# Altium schematic sheet PowerMonitor.SchDoc
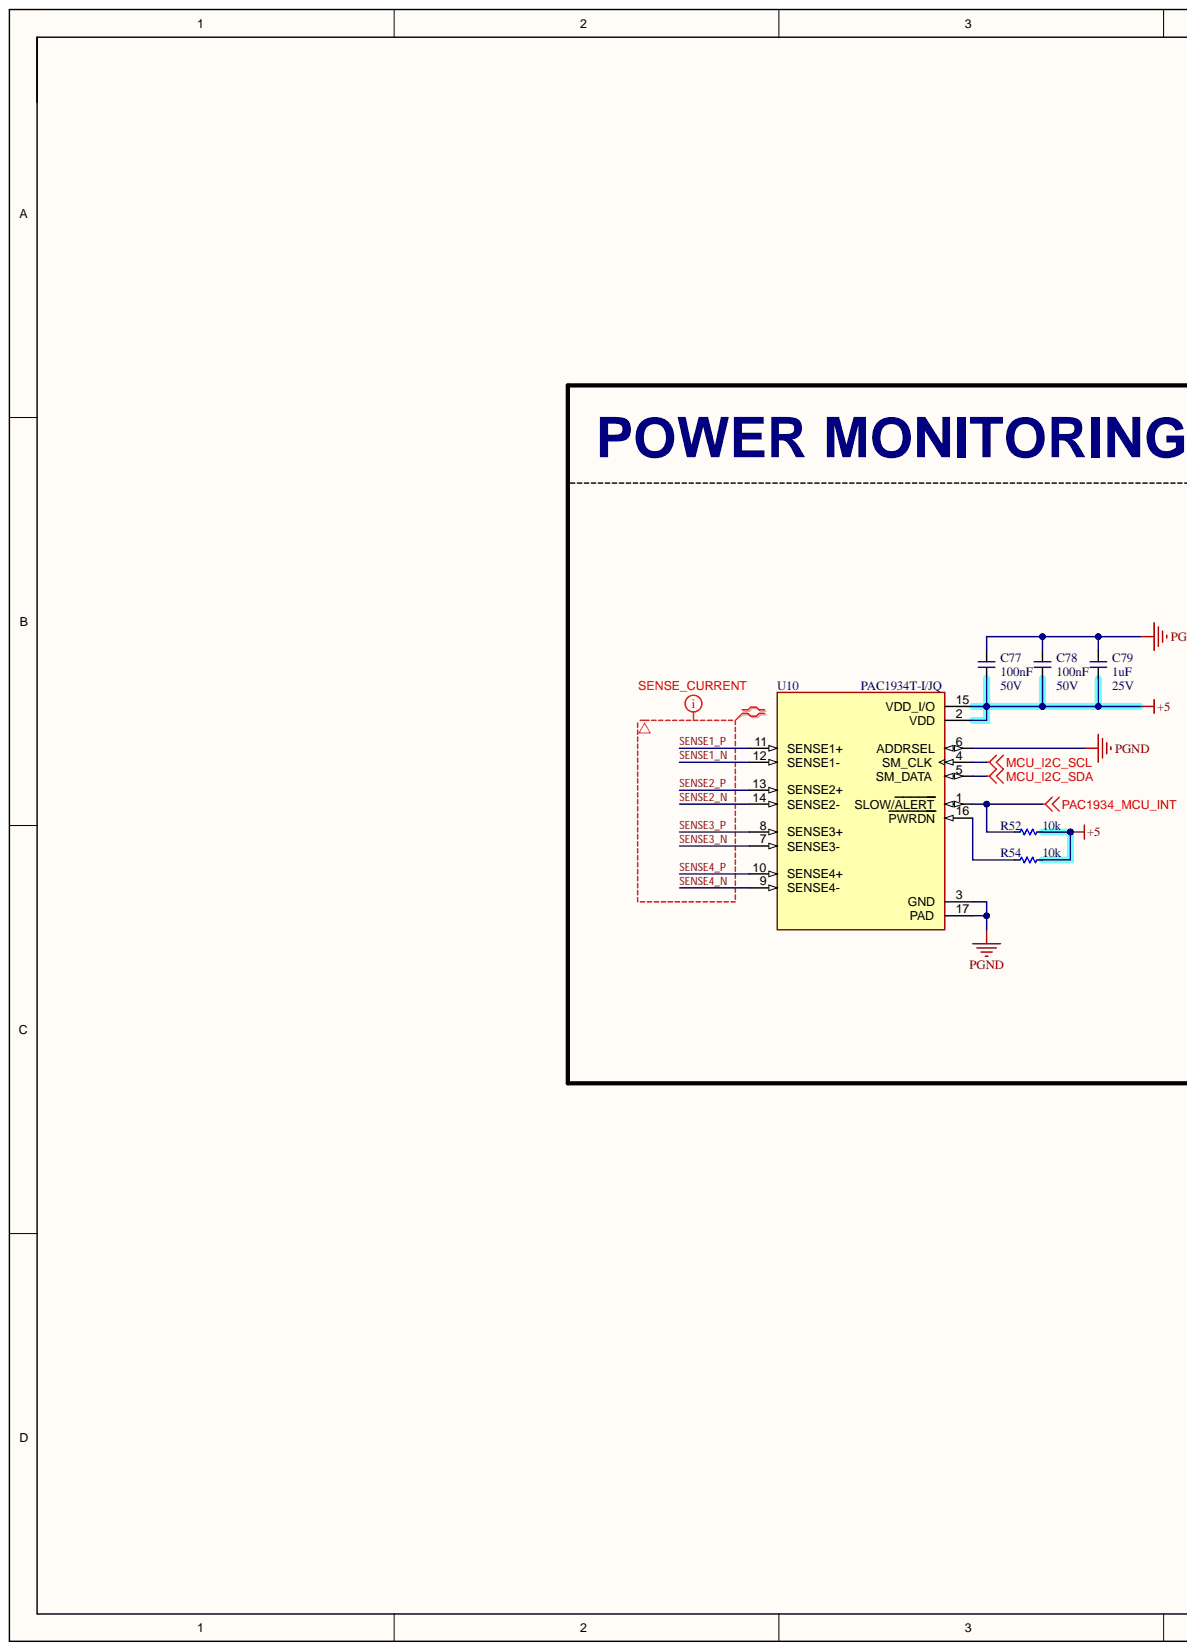
[diagram p0: PowerMonitor.SchDoc, left]
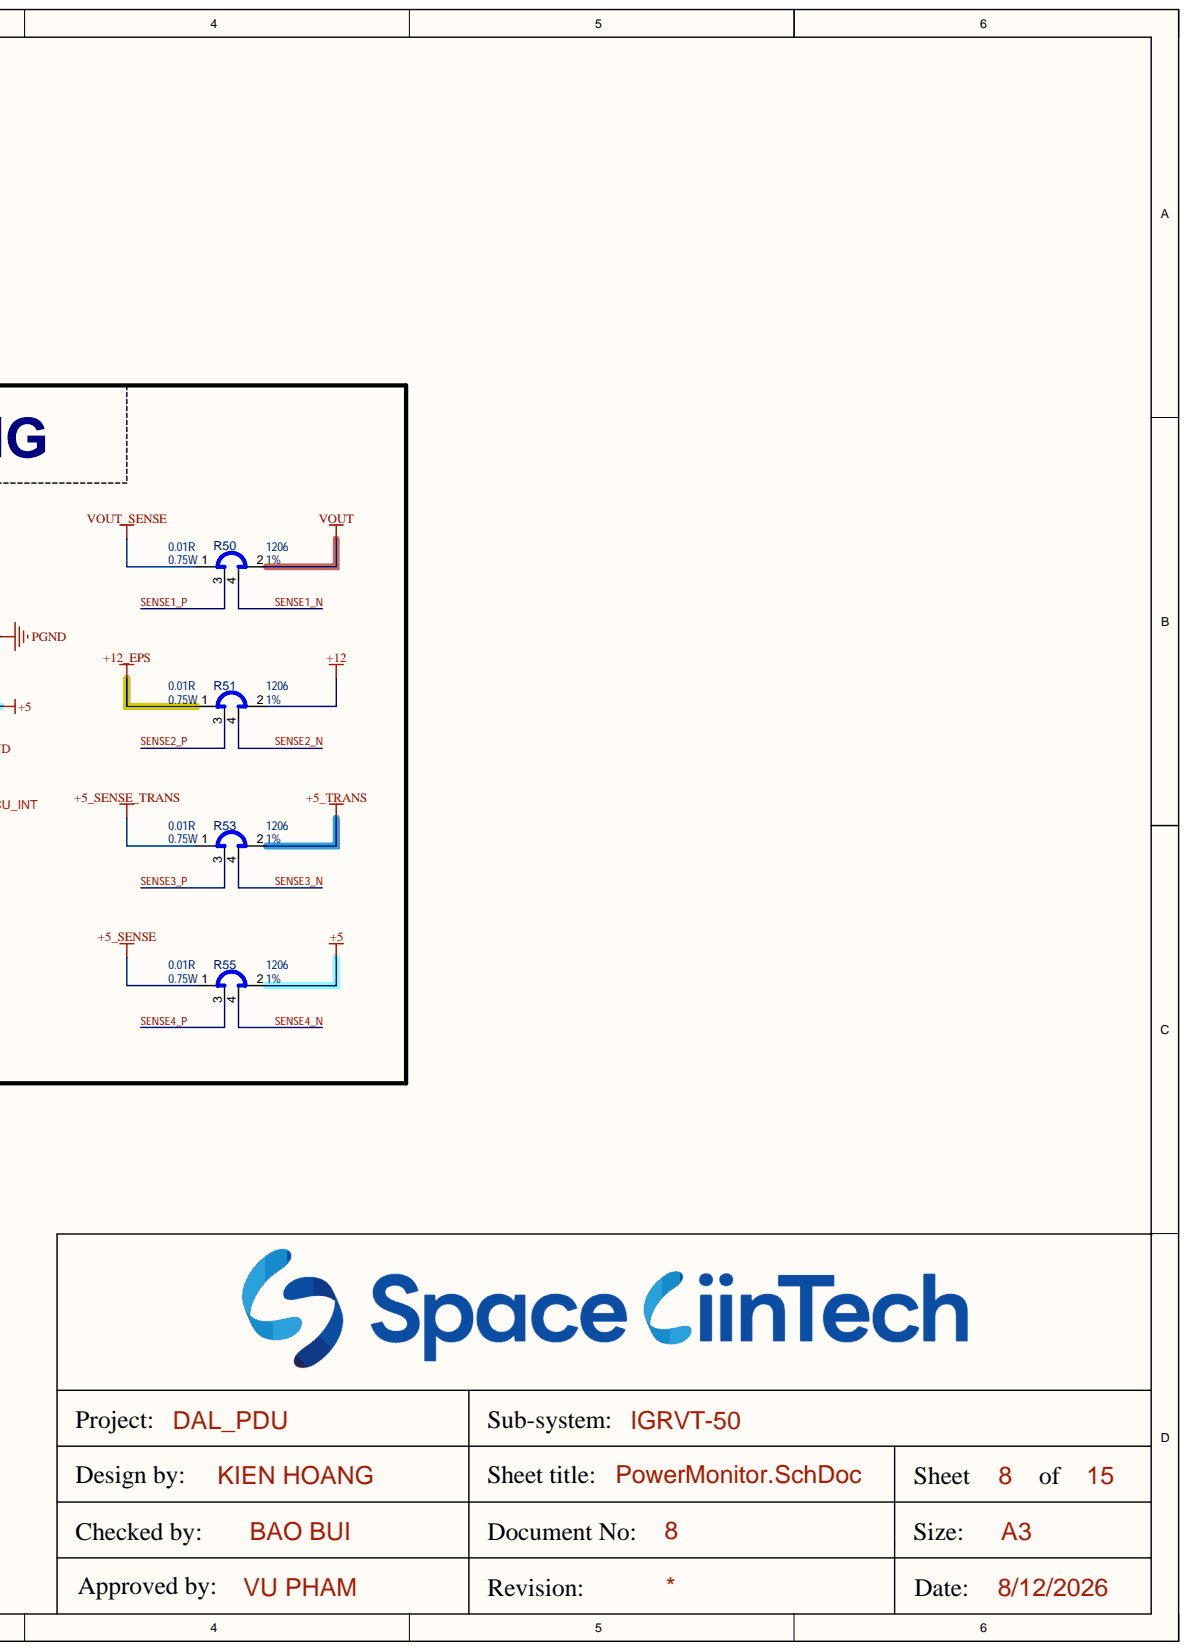
[diagram p1: PowerMonitor.SchDoc, right]

## Parts
C77  100nF  Voltage: 50V  [CAP_C_1608X80]
C78  100nF  Voltage: 50V  [CAP_C_1608X80]
C79  1uF  Voltage: 25V  [CAP_C_1608X80]
R50  value 0.01R  Package: 1206  Power: 0.75W  Tolerance: 1%  [RES_C_1206_SHUNT]  (hidden comment: Shunt 4 Pin)
R51  value 0.01R  Package: 1206  Power: 0.75W  Tolerance: 1%  [RES_C_1206_SHUNT]  (hidden comment: Shunt 4 Pin)
R52  10k  [RES_C_1608X60]
R53  value 0.01R  Package: 1206  Power: 0.75W  Tolerance: 1%  [RES_C_1206_SHUNT]  (hidden comment: Shunt 4 Pin)
R54  10k  [RES_C_1608X60]
R55  value 0.01R  Package: 1206  Power: 0.75W  Tolerance: 1%  [RES_C_1206_SHUNT]  (hidden comment: Shunt 4 Pin)
U10  PAC1934T-I/JQ  [QFN65]

## Nets
+5: C77.2 C78.2 C79.2 R52.1 R54.2 R55.2 U10.2(VDD) U10.15(VDD_I/O)
+5_SENSE: R55.1
+5_SENSE_TRANS: R53.1
+5_TRANS: R53.2
+12: R51.2
+12_EPS: R51.1
MCU_I2C_SCL: U10.4(SM_CLK)
MCU_I2C_SDA: U10.5(SM_DATA)
PAC1934_MCU_INT: R52.2 U10.1(SLOW/ALERT)
PGND: C77.1 C78.1 C79.1 U10.3(GND) U10.6(ADDRSEL) U10.17(PAD)
VOUT: R50.2
VOUT_SENSE: R50.1
SENSE1_N: R50.4 U10.12(SENSE1-)
SENSE1_P: R50.3 U10.11(SENSE1+)
SENSE2_N: R51.4 U10.14(SENSE2-)
SENSE2_P: R51.3 U10.13(SENSE2+)
SENSE3_N: R53.4 U10.7(SENSE3-)
SENSE3_P: R53.3 U10.8(SENSE3+)
SENSE4_N: R55.4 U10.9(SENSE4-)
SENSE4_P: R55.3 U10.10(SENSE4+)
NetR54_1: R54.1 U10.16(PWRDN)

## Library data of the parts
C77-C79 = _CAP_C_2: CAP; Component Kind: Standard; Library Name: LABLIB.SchLib
R50 R51 R53 R55 = RSMT1316: Shunt; Manufacturer 1: SUSUMU; Manufacturer Part Number 1: KRL1632E-M-R010-F-T5; Current: 8A; Description_: RES SHUNT MF 0.01R 1% 0.75W SMD 1206; Dev Tools Status: Design; Owner: Ciprian Abramov; Populated: YES; Temperature Coeffcient: 50ppm/C; Category: Resistor; Component Kind: Standard; ComponentLink1Description: SVN Datasheet; ComponentLink1URL: https://chn-vm-apps.microchip.com/mchprepo/parts/Datasheets/n_catalog_partition09_en.pdf; ComponentLink2Description: Local Datasheet; ComponentLink3Description: Open Symbol; ComponentLink4Description: Open Footprint; ComponentLink5Description: Open Footprint 2; ComponentLink6Description: Open Footprint 3; ComponentLink7Description: Open Footprint 4; Composition: MF; MCHP-ID: RSMT1316; Operating Temperature: -55C to +155C; Quantity Override: 1; Revision: 1; Status: MCL Design; Supplier 1: Digi-Key; Supplier Part Number 1: 408-1570-1-ND; Symbol: Shunt 4 Pin; Type: RES SMD; ComponentLink2URL: C:\ALTIUM_WORK\00001_MCHP-CDB\Datasheets\n_catalog_partition09_en.pdf; ComponentLink3URL: C:\ALTIUM_WORK\00001_MCHP-CDB\Library\LibraryRefs\Shunt 4 Pin.SchLib; ComponentLink4URL: C:\ALTIUM_WORK\00001_MCHP-CDB\Library\Footprints\RES_C_1206_SHUNT.PcbLib; Library Name: LABLIB.SchLib
R52 R54 = _RES_B_2_2: RES; Component Kind: Standard; Library Name: LABLIB.SchLib
U10 = PAC1934T-I/JQ: Component Kind: Standard; Library Name: new1.SchLib
